# Altium schematic sheet motordriver_low voltage.schdoc("motordriver_low voltage")
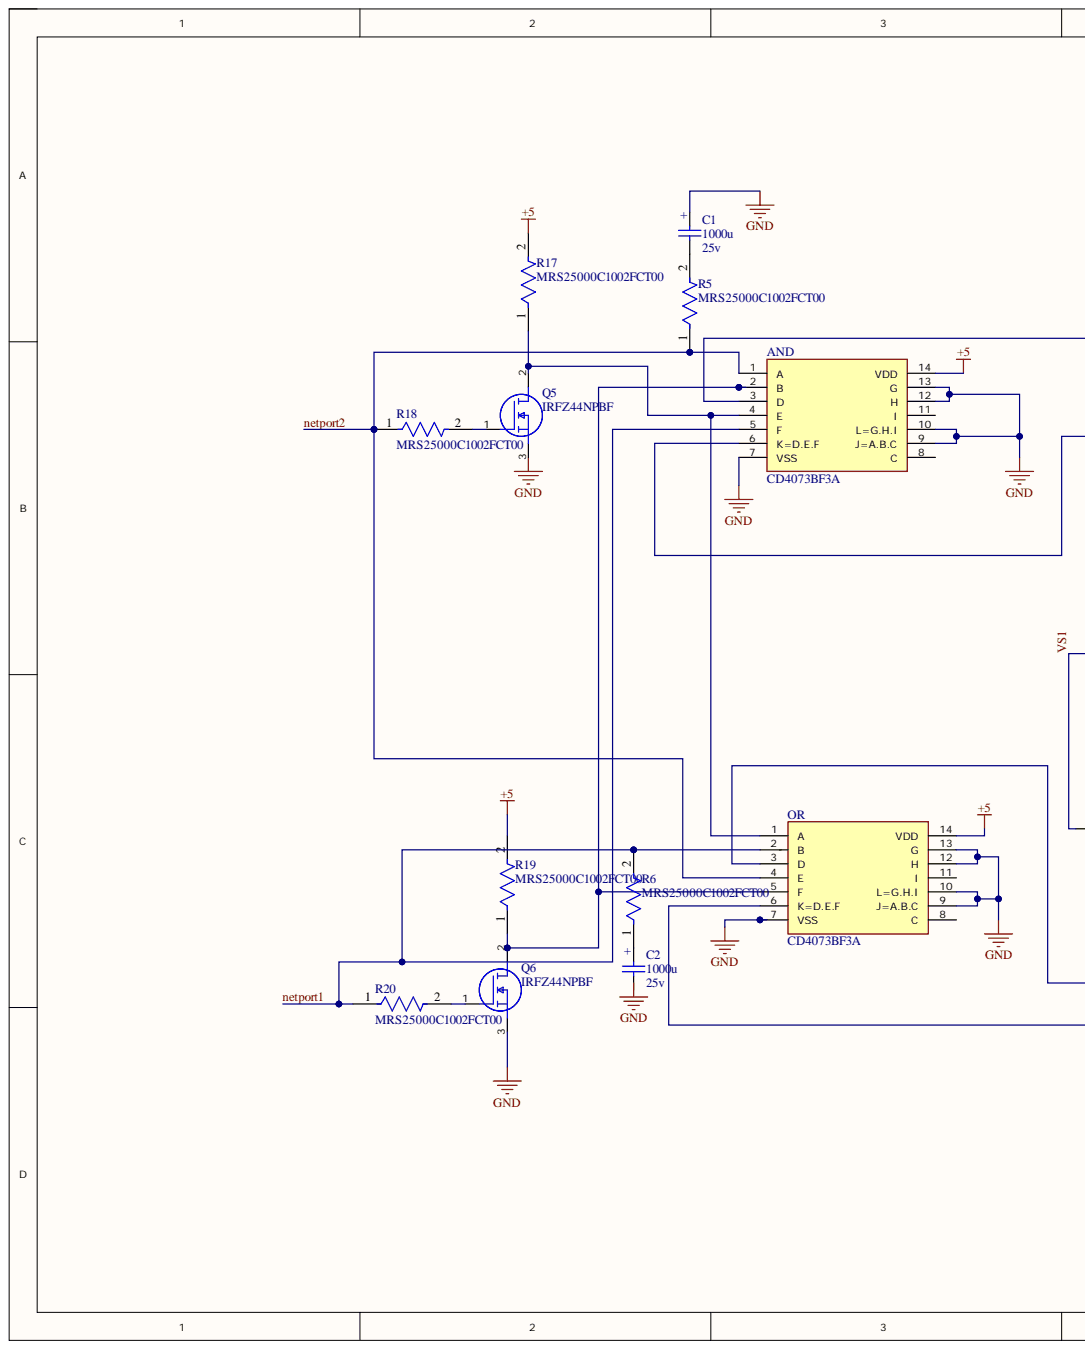
[diagram p0: motordriver_low voltage.schdoc, left]
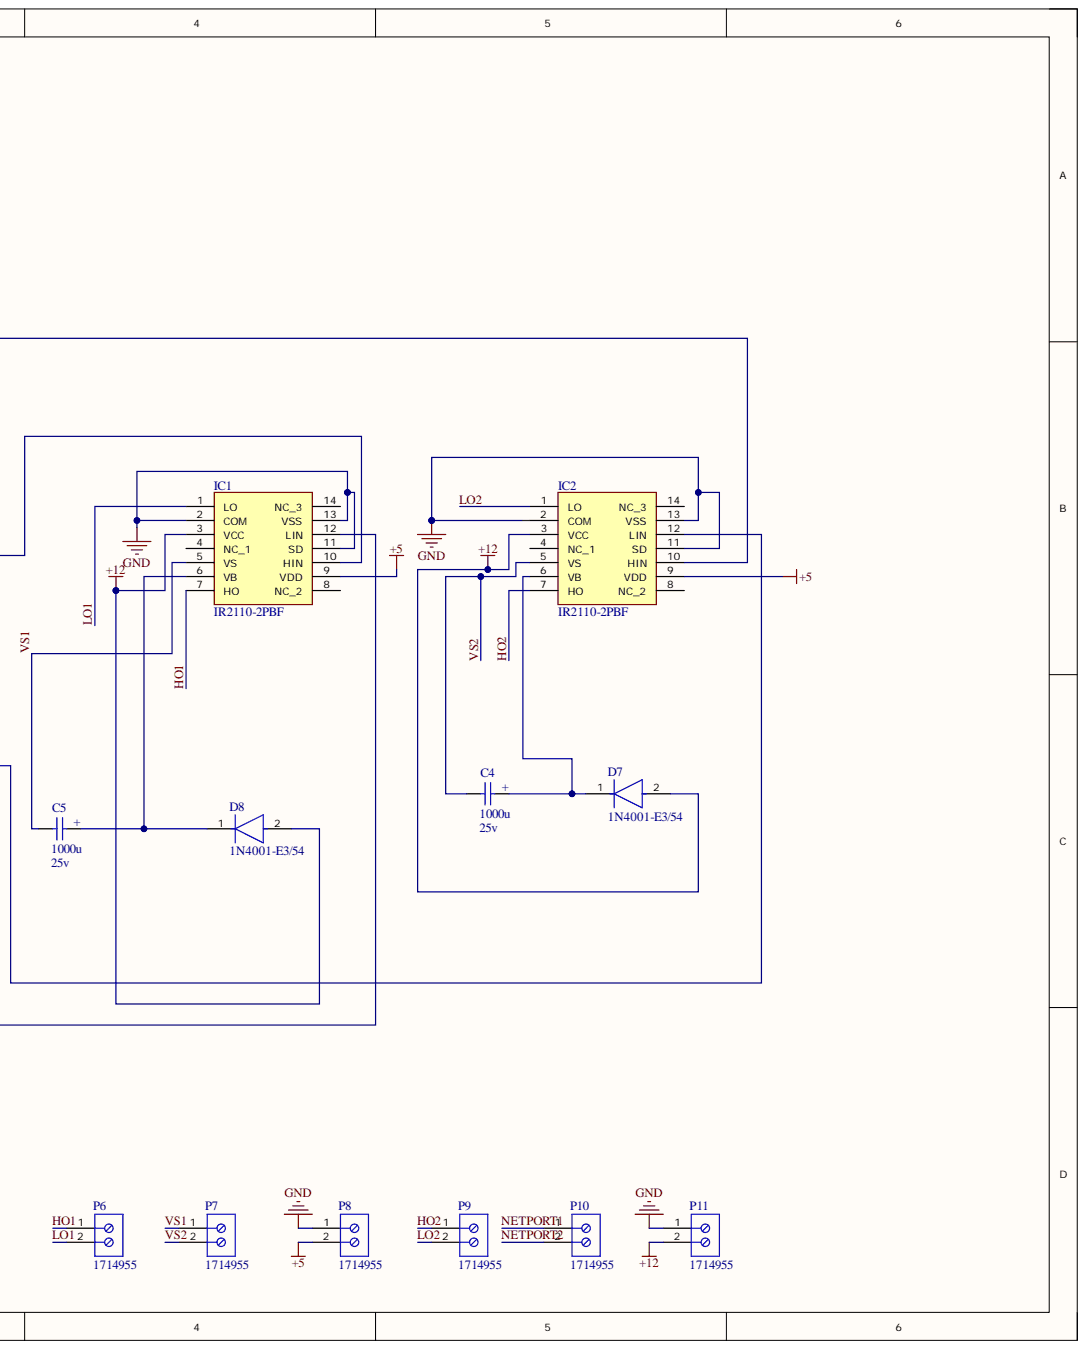
[diagram p1: motordriver_low voltage.schdoc, right]

## Parts
AND  CD4073BF3A  [CDIP790W66P254L1954H508Q14]
C1  1000u  Volte: 25v  [MY_E2.5-5mm]
C2  1000u  Volte: 25v  [MY_E2.5-5mm]
C4  1000u  Volte: 25v  [MY_E2.5-5mm]
C5  1000u  Volte: 25v  [MY_E2.5-5mm]
D7  1N4001-E3/54  [FP-1N4001-E3-54-MFG]
D8  1N4001-E3/54  [FP-1N4001-E3-54-MFG]
IC1  IR2110-2PBF  [DIP762W56P254L1930H533Q14N]
IC2  IR2110-2PBF  [DIP762W56P254L1930H533Q14N]
OR  CD4073BF3A  [CDIP790W66P254L1954H508Q14]
P6  1714955  [PHOE-1714955_V]
P7  1714955  [PHOE-1714955_V]
P8  1714955  [PHOE-1714955_V]
P9  1714955  [PHOE-1714955_V]
P10  1714955  [PHOE-1714955_V]
P11  1714955  [PHOE-1714955_V]
Q5  IRFZ44NPBF  [TO254P483X1016X1994-3P]
Q6  IRFZ44NPBF  [TO254P483X1016X1994-3P]
R5  MRS25000C1002FCT00  [FP-MRS25-MFG]
R6  MRS25000C1002FCT00  [FP-MRS25-MFG]
R17  MRS25000C1002FCT00  [FP-MRS25-MFG]
R18  MRS25000C1002FCT00  [FP-MRS25-MFG]
R19  MRS25000C1002FCT00  [FP-MRS25-MFG]
R20  MRS25000C1002FCT00  [FP-MRS25-MFG]

## Nets
+5: AND.14(VDD) IC1.9(VDD) IC2.9(VDD) OR.14(VDD) P8.2 R17.2 R19.2
+12: D7.2 D8.2 IC1.3(VCC) IC2.3(VCC) P11.2
GND: AND.7(VSS) AND.9(J=A.B.C) AND.10(L=G.H.I) AND.12(H) AND.13(G) C1.+ IC1.2(COM) IC1.11(SD) IC1.13(VSS) IC2.2(COM) IC2.11(SD) IC2.13(VSS) OR.7(VSS) OR.9(J=A.B.C) OR.10(L=G.H.I) OR.12(H) OR.13(G) P8.1 P11.1 Q5.3 Q6.3
HO1: IC1.7(HO) P6.1
HO2: IC2.7(HO) P9.1
LO1: IC1.1(LO) P6.2
LO2: IC2.1(LO) P9.2
netport1: AND.5(F) OR.2(B) P10.1 R6.2 R20.1
netport2: AND.1(A) OR.4(E) P10.2 R5.1 R18.1
VS1: C5.- IC1.5(VS) P7.1
VS2: C4.- IC2.5(VS) P7.2
NetAND_2: AND.2(B) OR.5(F) Q6.2 R19.1
NetAND_3: AND.3(D) IC2.10(HIN)
NetAND_4: AND.4(E) OR.1(A) Q5.2 R17.1
NetAND_6: AND.6(K=D.E.F) IC1.10(HIN)
NetC1_-: C1.- R5.2
NetC2_+: C2.+ R6.1
NetC4_+: C4.+ D7.1 IC2.6(VB)
NetC5_+: C5.+ D8.1 IC1.6(VB)
NetIC1_12: IC1.12(LIN) OR.6(K=D.E.F)
NetIC2_12: IC2.12(LIN) OR.3(D)
NetQ5_1: Q5.1 R18.2
NetQ6_1: Q6.1 R20.2

Not connected: AND 8(C) 11(I); C2 -; IC1 4(NC_1) 8(NC_2) 14(NC_3); IC2 4(NC_1) 8(NC_2) 14(NC_3); OR 8(C) 11(I)

## Library data of the parts
AND OR = CD4073BF3A: Integrated Circuit; Manufacturer_Name: Texas Instruments; Arrow Part Number: CD4073BF3A; Arrow Price/Stock: https://www.arrow.com/en/products/cd4073bf3a/texas-instruments?region=nac; Component Kind: Standard; Datasheet Link: https://www.ti.com/lit/ds/symlink/cd4073b-mil.pdf?ts=1634815353837&ref_url=https%253A%252F%252Fwww.ti.com%252Fstore%252Fti%252Fen%252Fp%252Fproduct%252F%253Fp%253DCD4073BF3A; Height: 5.08mm; Library Name: SamacSys.SchLib; Manufacturer_Part_Number: CD4073BF3A
C1 C2 C4 C5 = Cap Pol: Polar capacitor; ASiD Part Number: C-AS; Component Kind: Standard; Library Name: Mylib+Rev+4.IntLib; Value: 1000u
D7 D8 = CMP-02540-000077-1: DIODE GEN PURP 50V 1A DO204AL; Capacitance: 15pF; Element Configuration: Single; Forward Voltage: 1.1V; Max Forward Surge Current (Ifsm): 30A; Max Reverse Current: 5uA; Peak Non-Repetitive Surge Current: 45A; Polarity: Standard; Average Rectified Current: 1A; Case/Package: DO-204AL; Component Kind: Standard; Current: 1A; Current Rating: 1A; Diameter: 2.7mm; Forward Current: 1A; Height: 2.7mm; Lead Free: Lead Free; Length: 0.204inch; Library Name: Altium Content Vault; Max Operating Temperature: 150°C; Max Repetitive Reverse Voltage (Vrrm): 50V; Max Reverse Voltage (DC): 50V; Max Surge Current: 30A; Min Operating Temperature: -50°C; Mount: Through Hole; Number of Pins: 2; Output Current: 1A; Package Quantity: 5500; Packaging: Tape and Reel; Radiation Hardening: No; Reverse Voltage: 50V; RoHS Compliant: Yes; Series: -; Voltage: 50V; Voltage Rating (DC): 50V; Width: 2.7mm
IC1 IC2 = IR2110-2PBF: Integrated Circuit; Manufacturer_Name: Infineon; Arrow Part Number: IR2110-2PBF; Arrow Price/Stock: https://www.arrow.com/en/products/ir2110-2pbf/international-rectifier; Component Kind: Standard; Datasheet Link: https://www.digikey.com/product-detail/en/infineon-technologies/IR2110-2PBF/IR2110-2PBF-ND/811587; Height: 5.33mm; Library Name: SamacSys.SchLib; Manufacturer_Part_Number: IR2110-2PBF; Mouser Part Number: 942-IR2110-2PBF; Mouser Price/Stock: https://www.mouser.co.uk/ProductDetail/Infineon-Technologies/IR2110-2PBF?qs=dQmlx5f5cRLng%2FtY2dzXzA%3D%3D
P6-P11 = CMP-2000-05797-1: PC Terminal Block, Pitch 6.35 mm, 1 x 2 Position, Height 21.5 mm, Tail Lenght 5.1 mm, RoHS, Bulk; Manufacturer: Phoenix Contact; Component Kind: Standard; Datasheet URL: http://media.digikey.com/pdf/Data%20Sheets/Phoenix%20Contact%20PDFs/1714955.pdf; Datasheet Version: 02/2010; Library Name: Altium Content Vault; Manufacturer URL: http://www.phoenixcontact.com/; Mounting Technology: ThroughHole; Package Description: PC Terminal Block, Pitch 6.35 mm, 1 x 2 Position, Height 21.5 mm, Tail Lenght 5.1 mm; Package Reference: 1714955
Q5 Q6 = IRFZ44NPBF: MOSFET (N-Channel); Manufacturer_Name: Infineon; Arrow Part Number: IRFZ44NPBF; Arrow Price/Stock: https://www.arrow.com/en/products/irfz44npbf/infineon-technologies-ag?utm_currency=USD&region=europe; Component Kind: Standard; Datasheet Link: https://www.infineon.com/dgdl/irfz44npbf.pdf?fileId=5546d462533600a40153563b3a9f220d; Height: 4.83mm; Library Name: SamacSys.SchLib; Manufacturer_Part_Number: IRFZ44NPBF; Mouser Part Number: 942-IRFZ44NPBF; Mouser Price/Stock: https://www.mouser.co.uk/ProductDetail/Infineon-Technologies/IRFZ44NPBF?qs=9%252BKlkBgLFf24zghzPg2f9g%3D%3D
R5 R6 R17-R20 = CMP-00030-42632392-1: MRS25000C1002FCT00 Resistor, 10 kOhm, ± 1%, 600 mW, Axial Leaded, Metal Film (Thin Film), Professional; Lead Length: 26mm; Max Power Dissipation: 600mW; Resistance: 10kΩ; Temperature Coefficient: 50ppm/°C; Voltage Rating: 350V; Case/Package: Conformal; Component Kind: Standard; Composition: Metal Film, Thin Film; Contact Plating: Tin; Diameter: 2.5mm; Lead Free: Lead Free; Length: 6.5mm; Library Name: Altium Content Vault; Max Operating Temperature: 155°C; Military Standard: Not; Min Operating Temperature: -55°C; Mount: Through Hole; NexarPartId: 42632392; Number of Pins: 2; Number of Terminations: 2; Power Rating: 600mW; Radiation Hardening: No; REACH SVHC: Unknown; RoHS: Compliant; Schedule B: 8533210090, 8533210090; Termination: Axial; Tolerance: 1%; Voltage Rating (DC): 350V; Width: 2.5mm
